# Altium schematic sheet 100W_Inverter_V1_Sch.SchDoc(100W_Inverter_V1_Sch)
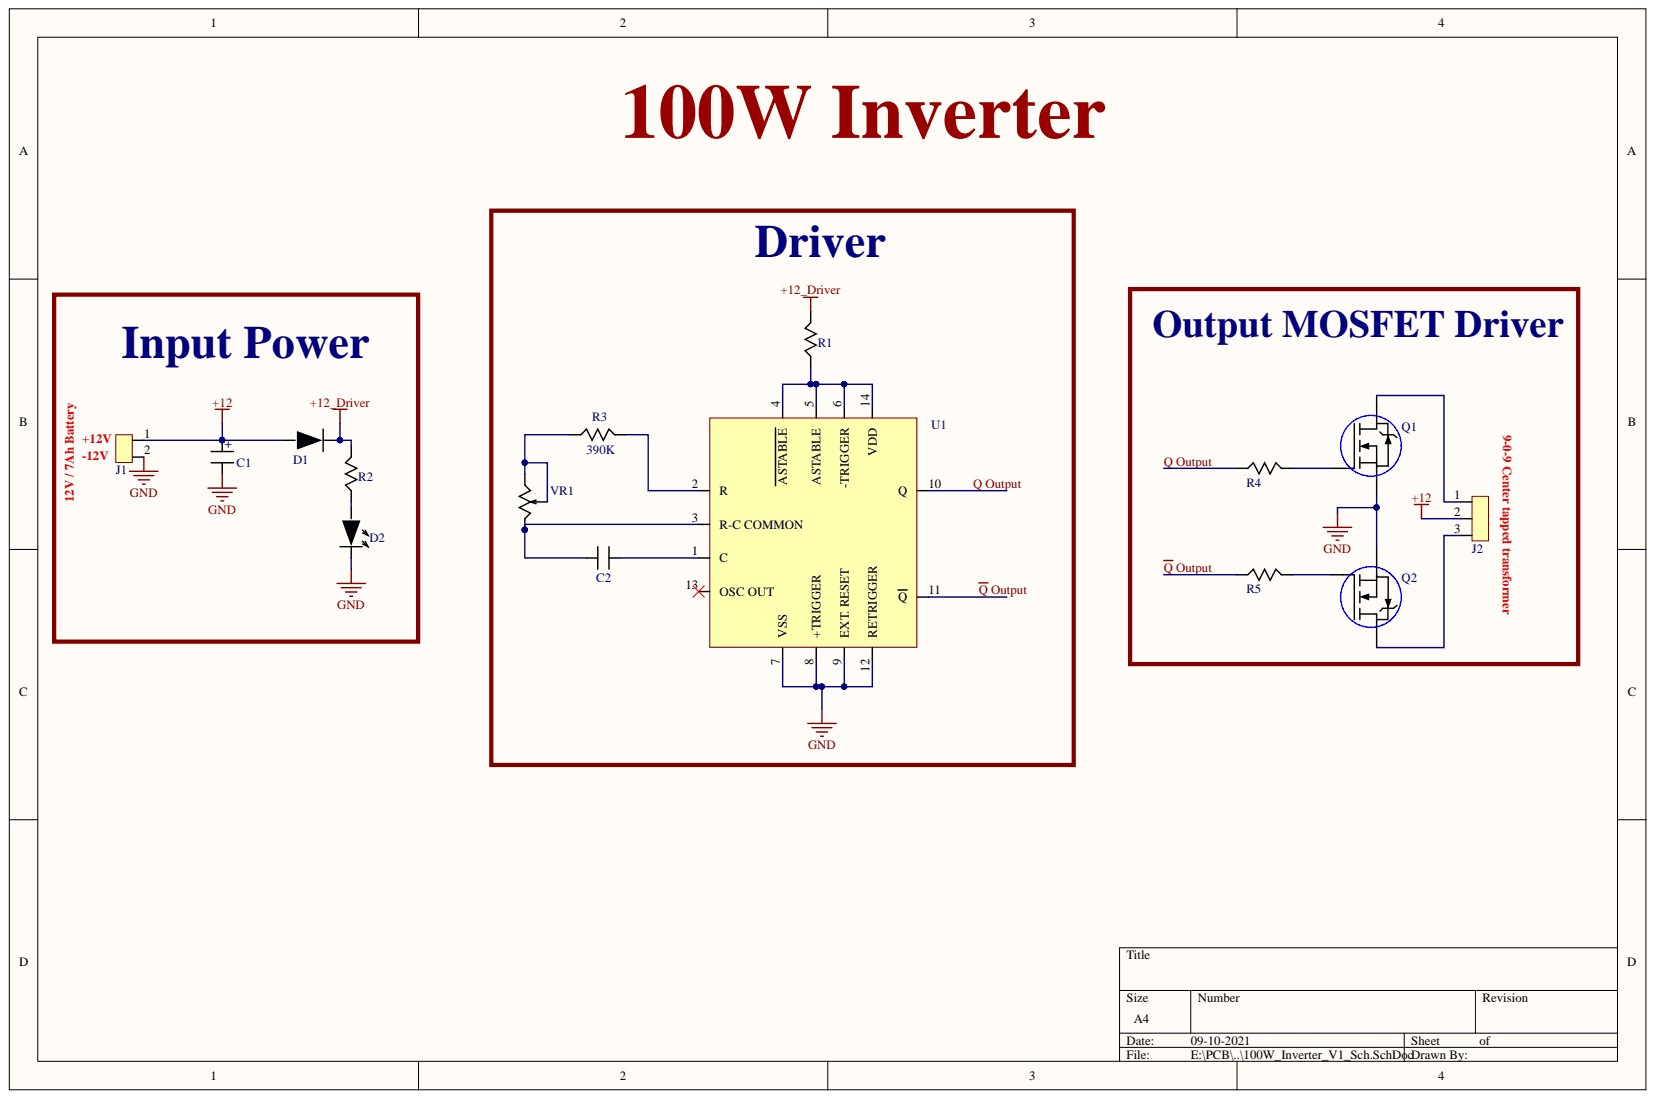
[diagram p0: 100W_Inverter_V1_Sch.SchDoc]

## Parts
C1  C_2200uF_Ely_35V_TH  [Ecap_8mmPitch_16d]  (value not drawn)
C2  C_0.01uF_Cer_50V_TH  [0.01uF_Cer_TH]  (value not drawn)
D1  1N4007  [Diode]  (value not drawn)
D2  LED_3mm_Red_TH  [LED_3MM_Red]  (value not drawn)
J1  TB_2P_5mmP  [TB_5mmP_2Pin]  (value not drawn)
J2  TB_3P_5mmP  [TB_5mmP_3Pin]  (value not drawn)
Q1  IRF540  [TO220]  (value not drawn)
Q2  IRF540  [TO220]  (value not drawn)
R1  R_330_0.25W_TH  [Axial 0.3]  (value not drawn)
R2  R_1K_0.25W_TH  [Axial 0.3]  (value not drawn)
R3  390K  [Axial 0.3]
R4  R_220_0.25W_TH  [Axial 0.3]  (value not drawn)
R5  R_220_0.25W_TH  [Axial 0.3]  (value not drawn)
U1  CD4047BE  [DIP14_8mmspace_2.54mmP]  (value not drawn)
VR1  VR_1K_trim_20T  [3290H]  (value not drawn)

## Nets
+12: C1.1 D1.1 J1.1 J2.2
+12_Driver: D1.2 R1.2 R2.2
GND: C1.2 D2.2 J1.2 Q1.3 Q2.3 U1.7(VSS) U1.8(+TRIGGER) U1.9(EXT._RESET) U1.12(RETRIGGER)
Q Output: R4.1 U1.10(Q)
~{Q} Output: R5.1 U1.11(Q)
NetC2_1: C2.1 U1.3(R-C_COMMON) VR1.3
NetC2_2: C2.2 U1.1(C)
NetD2_1: D2.1 R2.1
NetJ2_1: J2.1 Q1.2
NetJ2_3: J2.3 Q2.2
NetQ1_1: Q1.1 R4.2
NetQ2_1: Q2.1 R5.2
NetR1_1: R1.1 U1.4(ASTABLE) U1.5(ASTABLE) U1.6(-TRIGGER) U1.14(VDD)
NetR3_1: R3.1 VR1.1 VR1.2
NetR3_2: R3.2 U1.2(R)

Not connected: U1 13(OSC_OUT)

## Library data of the parts
C1 = C_2200uF_Ely_35V_TH: Aluminum Electrolytic Capacitor, Polarized, Aluminum (wet), 35V, 20% +Tol, 20% -Tol, 2200uF, Through Hole Mount; Manufacturer 1: CDE Illinois Capacitor; Manufacturer Part Number 1: 228CKR035M; Capacitance: 2.2mF; Dielectric Material: Aluminium; ESR (Equivalent Series Resistance): 121mR; Life (Hours): 2000Hour; Polarity: Polar; Ripple Current: 2.09A; Case/Package: Radial; Category: Aluminum Electrolytic Capacitors; Component Kind: Standard; ComponentLink1Description: https://datasheet.ciiva.com/16819/ckr-cks-16819885.pdf?src-supplier=Future+Electronics; ComponentLink1URL: https://datasheet.ciiva.com/16819/ckr-cks-16819885.pdf?src-supplier=Future+Electronics; Diameter: 16mm; Height: 31.5mm; Height - Seated (Max): 1.24inch; Lead Free: Lead Free; Lead Pitch: 0.295inch; Library Name: Sch_common.SchLib; Max Operating Temperature: 85°C; Min Operating Temperature: -40°C; Mount: Through Hole; Package Quantity: 50; Packaging: Bulk; Pricing 1: 1=1.52000, 10=1.20100, 50=1.04060, 100=0.90040, 500=0.68034, 1000=0.60030, 2500=0.56028, 5000=0.54027 (USD); REACH SVHC: No SVHC; RoHS: Yes; Stock 1: 3987; Supplier 1: Digi-Key; Tolerance: 20%; Voltage Rating: 35V; Voltage Rating (DC): 35V
C2 = C_0.01uF_Cer_50V_TH: Capacitor Ceramic 0.01uF 50V C0G 5% 5mm T/R; Manufacturer 1: TDK; Manufacturer Part Number 1: FA28NP01H103JNU06; Capacitance: 10nF; Lead/Base Style: Kinked; Case/Package: Radial; Category: Ceramic Capacitors; Component Kind: Standard; ComponentLink1Description: https://product.tdk.com/info/en/catalog/datasheets/leadmlcc_halogenfree_fa150_en.pdf?src-supplier=Digi-Key; ComponentLink1URL: https://product.tdk.com/info/en/catalog/datasheets/leadmlcc_halogenfree_fa150_en.pdf?src-supplier=Digi-Key; Features: High Temperature; Height - Seated (Max): 0.217inch; Lead Pitch: 0.197inch; Length: 0.157inch; Library Name: Sch_common.SchLib; Max Operating Temperature: 150°C; Min Operating Temperature: -55°C; Mount: Through Hole; Packaging: Tape and Reel; Pricing 1: 1=0.48000, 10=0.33700, 50=0.25500, 100=0.22220, 500=0.16456, 1000=0.13988 (USD); Ratings: AEC-Q200; RoHS: Yes; RoHS Compliant: Yes; Stock 1: 2762; Supplier 1: Digi-Key; Tolerance: 5%; Voltage Rating: 50V; Width: 0.098inch
D1 = 1N4007: Diode Switching 1KV 1A 2-Pin DO-41 T/R; Manufacturer 1: Diodes; Manufacturer Part Number 1: 1N4007G-T; Capacitance: 8pF; Element Configuration: Single; Forward Voltage: 1V; Max Reverse Current: 5uA; Max Surge Current: 30A; Polarity: Standard; Recovery Time: 2us; Average Rectified Current: 1A; Case/Package: DO-41; Category: Rectifier Diodes; Component Kind: Standard; ComponentLink1Description: https://datasheet.ciiva.com/36525/1537942-36525995.pdf?src-supplier=Jameco; ComponentLink1URL: https://datasheet.ciiva.com/36525/1537942-36525995.pdf?src-supplier=Jameco; ComponentLink2Description: https://datasheet.ciiva.com/15219/getdatasheetpartid-213960-15219547.pdf?src-supplier=Verical; ComponentLink2URL: https://datasheet.ciiva.com/15219/getdatasheetpartid-213960-15219547.pdf?src-supplier=Verical; ComponentLink3Description: https://datasheet.ciiva.com/25947/ds29002-25947029.pdf?src-supplier=Avnet+Europe; ComponentLink3URL: https://datasheet.ciiva.com/25947/ds29002-25947029.pdf?src-supplier=Avnet+Europe; ComponentLink4Description: https://datasheet.ciiva.com/912/ds29002-912230.pdf?src-supplier=Digi-Key; ComponentLink4URL: https://datasheet.ciiva.com/912/ds29002-912230.pdf?src-supplier=Digi-Key; ComponentLink5Description: https://datasheet.ciiva.com/16395/ds29002-16395514.pdf?src-supplier=Future+Electronics; ComponentLink5URL: https://datasheet.ciiva.com/16395/ds29002-16395514.pdf?src-supplier=Future+Electronics; Current Rating: 1A; Forward Current: 1A; Height: 2.71mm; Lead Free: Lead Free; Length: 4.7mm; Library Name: Sch_common.SchLib; Max Operating Temperature: 175°C; Max Repetitive Reverse Voltage (Vrrm): 1kV; Max Reverse Voltage (DC): 1kV; Min Operating Temperature: -65°C; Mount: Through Hole; Number of Pins: 2; Output Current: 1A; Package Quantity: 5000; Packaging: Tape and Reel; Peak Non-Repetitive Surge Current: 30A; Pricing 1: 1=0.30000, 10=0.24800, 100=0.13170, 500=0.08662, 1000=0.05890, 2000=0.05313 (USD); Radiation Hardening: No; Reverse Recovery Time: 2us; Reverse Voltage (DC): 1kV; RoHS: Yes; RoHS Compliant: Yes; Series: -; Stock 1: 144958; Supplier 1: Digi-Key; Voltage Rating (DC): 1kV; Weight: 0.010582oz; Width: 2.71mm
D2 = LED_3mm_Red_TH: Manufacturer 1: SunLED; Manufacturer Part Number 1: XLMDK34D; Category: LEDs; Component Kind: Standard; Library Name: Sch_common.SchLib; Pricing 1: 1=0.38000, 10=0.30000, 100=0.13470, 500=0.12168, 1000=0.09996, 2000=0.08692, 5000=0.07823, 10000=0.06954, 25000=0.06519 (USD); RoHS: No; Stock 1: 8836; Supplier 1: Digi-Key
J1 = TB_2P_5mmP: TERM BLOCK PLUG 2POS 90DEG 5MM; Manufacturer 1: On-Shore Technology; Manufacturer Part Number 1: OSTTH020100; Current: 15A; Number of Levels: 1; Number of Positions: 2; Pitch: 0.197inch; Voltage: 300V; Wire Gauge (Max): 22AWG; Wire Gauge (Min): 14AWG; Category: Terminal Blocks; Color: Black; Component Kind: Standard; ComponentLink1Description: http://www.on-shore.com/wp-content/uploads/2015/09/ostthxx0160.pdf?src-supplier=Digi-Key; ComponentLink1URL: http://www.on-shore.com/wp-content/uploads/2015/09/ostthxx0160.pdf?src-supplier=Digi-Key; Contact Plating: Nickel; Library Name: Sch_common.SchLib; Max Operating Temperature: 115°C; Min Operating Temperature: -40°C; Mount: Free Hanging; Number of Pins: 2; Number of Positions Per Level: 2; Packaging: Bulk; Pricing 1: 1=0.86000, 10=0.53400, 25=0.45680, 50=0.41080, 100=0.40220, 250=0.34232, 500=0.32518, 1000=0.29096, 5000=0.22820 (USD); RoHS: Yes; RoHS Compliant: No; Series: OSTTH; Stock 1: 527; Supplier 1: Digi-Key; Termination: Screw
J2 = TB_3P_5mmP: Res Thick Film 0603 100K Ohm 1% 0.1W(1/10W) ±100ppm/C Pad SMD Automotive T/R; Manufacturer 1: Panasonic; Manufacturer Part Number 1: ERJ3EKF1003V; Max Power Dissipation: 100mW; Resistance: 100kR; Temperature Coefficient: 100ppm/°C; Voltage Rating: 75V; Case Code (Imperial): 0603; Case Code (Metric): 1608; Case/Package: 0603; Category: Chip SMD Resistors; Component Kind: Standard; ComponentLink1Description: https://datasheet.ciiva.com/34303/1987476-34303566.pdf?src-supplier=Jameco; ComponentLink1URL: https://datasheet.ciiva.com/34303/1987476-34303566.pdf?src-supplier=Jameco; ComponentLink2Description: https://datasheet.ciiva.com/16770/70260748-16770253.pdf?src-supplier=Allied+Electronics; ComponentLink2URL: https://datasheet.ciiva.com/16770/70260748-16770253.pdf?src-supplier=Allied+Electronics; ComponentLink3Description: https://datasheet.ciiva.com/16740/aoa0000ce2-16740662.pdf?src-supplier=Future+Electronics; ComponentLink3URL: https://datasheet.ciiva.com/16740/aoa0000ce2-16740662.pdf?src-supplier=Future+Electronics; ComponentLink4Description: https://datasheet.ciiva.com/15158/getdatasheetpartid-247527-15158907.pdf?src-supplier=Verical; ComponentLink4URL: https://datasheet.ciiva.com/15158/getdatasheetpartid-247527-15158907.pdf?src-supplier=Verical; ComponentLink5Description: https://datasheet.ciiva.com/30133/aoa0000ce2-30133845.pdf?src-supplier=Onlinecomponents.com; ComponentLink5URL: https://datasheet.ciiva.com/30133/aoa0000ce2-30133845.pdf?src-supplier=Onlinecomponents.com; Construction: Rectangular; Contact Plating: Tin; Depth: 0.8mm; Features: Automotive AEC-Q200; Height: 0.022inch; Lead Free: Lead Free; Length: 1.6mm; Library Name: Sch_common.SchLib; Max Operating Temperature: 155°C; Military Standard: Not; Min Operating Temperature: -55°C; Mount: Surface Mount; Number of Pins: 2; Number of Terminations: 2; Package Quantity: 5000; Packaging: Tape and Reel; Power Rating: 100mW; Pricing 1: 1=0.10000, 10=0.03700, 100=0.01490, 1000=0.00670, 2500=0.00582 (USD); Radiation Hardening: No; REACH SVHC: No SVHC; Resistance Tolerance: 1%; Resistor Type: Thick Film; RoHS: Yes; RoHS Compliant: Yes; Series: ERJ; Size Code: 0603; Stock 1: 10082; Supplier 1: Digi-Key; Termination: SMD/SMT; Tolerance: 1%; Voltage Rating (DC): 75V; Weight: 7.1E-05oz; Width: 0.8mm
Q1 Q2 = IRF540: Mosfet, Power; N-ch; Vdss 100V; Rds(on) 21MILLIOHMS; Id 36A; TO-262; Pd 92W; Vgs +/-20; Manufacturer 1: Infineon; Manufacturer Part Number 1: IRF540ZLPBF; Drain to Source Resistance: 26.5mR; Gate to Source Voltage (Vgs): 20V; Input Capacitance: 1.77nF; Turn-Off Delay Time: 43ns; Turn-On Delay Time: 15ns; Case/Package: TO-262; Category: MOSFETs; Component Kind: Standard; ComponentLink1Description: https://www.alliedelec.com/m/d/23899d70707f5ac9a5c306f9e15d4494.pdf?src-supplier=Allied+Electronics; ComponentLink1URL: https://www.alliedelec.com/m/d/23899d70707f5ac9a5c306f9e15d4494.pdf?src-supplier=Allied+Electronics; ComponentLink2Description: http://www.infineon.com/dgdl/irf540zpbf.pdf?fileId=5546d462533600a4015355e3af7d19a6&src-supplier=Digi-Key; ComponentLink2URL: http://www.infineon.com/dgdl/irf540zpbf.pdf?fileId=5546d462533600a4015355e3af7d19a6&src-supplier=Digi-Key; Continuous Drain Current (ID): 36A; Drain to Source Breakdown Voltage: 100V; Drain to Source Voltage (Vdss): 100V; Halogen Free: Halogen Free; Height: 0.38inch; Lead Free: Contains Lead; Length: 0.42inch; Library Name: Sch_common.SchLib; Max Dual Supply Voltage: 100V; Max Operating Temperature: 175°C; Max Power Dissipation: 92W; Min Operating Temperature: -55°C; Mount: Through Hole; Number of Pins: 3; Packaging: Rail/Tube; Power Dissipation: 92W; Rds On Max: 26.5mR; RoHS: Yes; RoHS Compliant: Yes; Supplier 1: Newark; Width: 0.19inch
R1 = R_330_0.25W_TH: TE Connectivity CFR16 Series Axial Carbon Film Resistor 330 5% 0.25W -1200  0ppm/C; Manufacturer Part Number 1: CFR16J330RJIT; Power Rating: 250mW; Resistance: 330R; Temperature Coefficient: 0ppm/°C; Case/Package: Conformal; Component Kind: Standard; ComponentLink1Description: https://datasheet.ciiva.com/11823/0900766b80098b49-11823361.pdf?src-supplier=RSComponents; ComponentLink1URL: https://datasheet.ciiva.com/11823/0900766b80098b49-11823361.pdf?src-supplier=RSComponents; Diameter: 1.85mm; Length: 3.5mm; Library Name: Sch_common.SchLib; Manufacturer 1: TE Connectivity; Max Operating Temperature: 155°C; Min Operating Temperature: -55°C; RoHS: Yes; Stock 1: 1; Supplier 1: RSComponents; Termination: Axial; Tolerance: 5%
R2 = R_1K_0.25W_TH: TE Connectivity CFR16 Series Axial Carbon Film Resistor 1k 5% 0.25W -1200  0ppm/C; Manufacturer Part Number 1: CFR16J1K0; Max Power Dissipation: 250mW; Number of Resistors: 1; Resistance: 1kR; Temperature Coefficient: 0ppm/°C; Voltage Rating: 200V; Case/Package: Axial; Category: Through-Hole Resistors; Component Kind: Standard; ComponentLink1Description: https://datasheet.ciiva.com/11823/0900766b80098b49-11823166.pdf?src-supplier=RSComponents; ComponentLink1URL: https://datasheet.ciiva.com/11823/0900766b80098b49-11823166.pdf?src-supplier=RSComponents; ComponentLink2Description: https://datasheet.ciiva.com/24428/eng-ds-1773195-d-24428424.pdf?src-supplier=Onlinecomponents.com; ComponentLink2URL: https://datasheet.ciiva.com/24428/eng-ds-1773195-d-24428424.pdf?src-supplier=Onlinecomponents.com; ComponentLink3Description: https://datasheet.ciiva.com/22664/1723854-22664943.pdf?src-supplier=Element14; ComponentLink3URL: https://datasheet.ciiva.com/22664/1723854-22664943.pdf?src-supplier=Element14; Diameter: 1.85mm; ELV: Compliant; Length: 3.5mm; Library Name: Sch_common.SchLib; Manufacturer 1: TE Connectivity; Max Operating Temperature: 155°C; Min Operating Temperature: -55°C; Mount: Axial; Number of Terminations: 2; Packaging: Cut Tape; Power Rating: 250mW; Pricing 1: 1=0.10000, 10=0.07900, 25=0.05440, 50=0.04240, 100=0.03020, 250=0.02360, 500=0.01876, 1000=0.01331 (USD); Radiation Hardening: No; REACH SVHC: No SVHC; Resistance Tolerance: 5%; Resistor Type: Thin Film; RoHS: Yes; RoHS Compliant: Yes; Series: CFR16, Neohm; Stock 1: 4940; Supplier 1: Digi-Key; Technology: CARBON FILM; Termination: Axial; Tolerance: 5%; Voltage Rating (DC): 200V
R3 = R_390K_0.25W_TH: RES 390K OHM 1/4W 5% AXIAL; Manufacturer 1: Yageo; Manufacturer Part Number 1: CFR-25JB-52-390K; Max Power Dissipation: 250mW; Resistance: 390kR; Temperature Coefficient: -700ppm/°C; Case/Package: Axial; Category: Through-Hole Resistors; Component Kind: Standard; ComponentLink1Description: https://datasheet.ciiva.com/2713/yageolr-cfr-2013-2713170.pdf?src-supplier=Digi-Key; ComponentLink1URL: https://datasheet.ciiva.com/2713/yageolr-cfr-2013-2713170.pdf?src-supplier=Digi-Key; Lead Free: Lead Free; Length: 0.248inch; Library Name: Sch_common.SchLib; Max Operating Temperature: 155°C; Min Operating Temperature: -55°C; Number of Terminations: 2; Package Quantity: 200; Packaging: Bulk; Pricing 1: 1=0.10000, 10=0.06700, 25=0.04640, 50=0.03600, 100=0.02580, 250=0.02008, 500=0.01596, 1000=0.01133, 5000=0.00876 (USD); Resistor Type: Carbon Film; RoHS: Yes; RoHS Compliant: Yes; Series: CFR; Stock 1: 11679; Supplier 1: Digi-Key; Tolerance: 5%
R4 R5 = R_220_0.25W_TH: RES 220 OHM 1/4W 5% AXIAL; Manufacturer 1: Stackpole Electronics; Manufacturer Part Number 1: CF14JT220R; Max Power Dissipation: 250mW; Resistance: 220R; Temperature Coefficient: -400ppm/°C; Case/Package: Conformal; Category: Through-Hole Resistors; Component Kind: Standard; ComponentLink1Description: https://www.seielect.com/catalog/SEI-Packaging.pdf?src-supplier=Digi-Key; ComponentLink1URL: https://www.seielect.com/catalog/SEI-Packaging.pdf?src-supplier=Digi-Key; ComponentLink2Description: https://www.seielect.com/catalog/SEI-CF_CFM.pdf?src-supplier=Avnet; ComponentLink2URL: https://www.seielect.com/catalog/SEI-CF_CFM.pdf?src-supplier=Avnet; ComponentLink3Description: https://www.verical.com/server-webapp/html/getDatasheet?partID=375100&src-supplier=Verical; ComponentLink3URL: https://www.verical.com/server-webapp/html/getDatasheet?partID=375100&src-supplier=Verical; ComponentLink4Description: http://components.arrow.com/part/dataview/44988614S316465N1174?src-supplier=Arrow+Electronics; ComponentLink4URL: http://components.arrow.com/part/dataview/44988614S316465N1174?src-supplier=Arrow+Electronics; Construction: Cylindrical; Contact Plating: Tin,Matte; Diameter: 2.3mm; Features: Flame Retardant Coating; Lead Free: Lead Free; Length: 6.5mm; Library Name: Sch_common.SchLib; Max Operating Temperature: 155°C; Military Standard: Not; Min Operating Temperature: -55°C; Mount: Through Hole,Axial; Number of Terminations: 2; Package Quantity: 1; Packaging: Tape and Reel; Power Rating: 250mW; Pricing 1: 1=0.10000, 10=0.03600, 25=0.02640, 50=0.02000, 100=0.01480, 250=0.01124, 500=0.00900, 1000=0.00662 (USD); Radiation Hardening: No; Resistor Type: Carbon Film; RoHS: Yes; RoHS Compliant: Yes; Series: CF; Stock 1: 142794; Supplier 1: Digi-Key; Termination: Axial; Tolerance: 5%
U1 = CD4047BE: MONO/ASTABLE MULTIVIBRATOR, 125NS, DIP-14; Multivibrator Type:Monostable / Astable; Output Current:6.8A; Supply Voltage Min:3V; Supply Voltage Max:18V; Logic Case Style:DIP; No. of Pins:14; Propagation Delay:125ns; Packaging:Each ;RoHS Compliant: Yes; Manufacturer 1: Texas Instruments; High Level Output Current: -4.2mA; Independent Circuits: 1; Input Capacitance: 5pF; Low Level Output Current: 4.2mA; Max Power Dissipation: 500mW; Max Supply Current: 20uA; Max Supply Voltage: 18V; Min Supply Voltage: 3V; Operating Supply Voltage: 12V; Output Current: 6.8mA; Schmitt Trigger Input: No; Turn-On Delay Time: 300ns; Case/Package: DIP; Category: Logic ICs; Component Kind: Standard; ComponentLink1Description: https://datasheet.ciiva.com/14134/cd4047b-eng-tds-14134622.pdf?src-supplier=Distrelec; ComponentLink1URL: https://datasheet.ciiva.com/14134/cd4047b-eng-tds-14134622.pdf?src-supplier=Distrelec; ComponentLink2Description: https://datasheet.ciiva.com/27050/894331-27050683.pdf?src-supplier=Jameco; ComponentLink2URL: https://datasheet.ciiva.com/27050/894331-27050683.pdf?src-supplier=Jameco; ComponentLink3Description: https://datasheet.ciiva.com/15095/getdatasheetpartid-72002-15095147.pdf?src-supplier=Verical; ComponentLink3URL: https://datasheet.ciiva.com/15095/getdatasheetpartid-72002-15095147.pdf?src-supplier=Verical; ComponentLink4Description: https://datasheet.ciiva.com/41455/001015487-da-01-en-ic-mono-astbl-multi-cd4047be-pdip-14-tid-41455405.pdf?src-supplier=Conrad; ComponentLink4URL: https://datasheet.ciiva.com/41455/001015487-da-01-en-ic-mono-astbl-multi-cd4047be-pdip-14-tid-41455405.pdf?src-supplier=Conrad; ComponentLink5Description: https://datasheet.ciiva.com/29297/173223-da-01-en-c-mos-ic-cd-4047-be-dip14-tid-29297339.pdf?src-supplier=Conrad; ComponentLink5URL: https://datasheet.ciiva.com/29297/173223-da-01-en-c-mos-ic-cd-4047-be-dip14-tid-29297339.pdf?src-supplier=Conrad; ComponentLink6Description: https://datasheet.ciiva.com/1509/1830980-1509169.pdf?src-supplier=Element14; ComponentLink6URL: https://datasheet.ciiva.com/1509/1830980-1509169.pdf?src-supplier=Element14; ComponentLink7Description: https://datasheet.ciiva.com/1885/cd4047b-1885142.pdf?src-supplier=Digi-Key; ComponentLink7URL: https://datasheet.ciiva.com/1885/cd4047b-1885142.pdf?src-supplier=Digi-Key; ComponentLink8Description: https://datasheet.ciiva.com/3305/cd4047b-3305349.pdf?src-supplier=Avnet; ComponentLink8URL: https://datasheet.ciiva.com/3305/cd4047b-3305349.pdf?src-supplier=Avnet; ComponentLink9Description: https://datasheet.ciiva.com/26242/cd4047b-26242053.pdf?src-supplier=Avnet+Europe; ComponentLink9URL: https://datasheet.ciiva.com/26242/cd4047b-26242053.pdf?src-supplier=Avnet+Europe; Contact Plating: Gold; Height: 4.57mm; Lead Free: Lead Free; Length: 19.3mm; Library Name: Sch_common.SchLib; Logic Function: Multivibrator; Manufacturer Part Number 1: CD4047BE; Max Operating Temperature: 125°C; Min Operating Temperature: -55°C; Mount: Through Hole; Number of Bits: 1; Number of Circuits: 1; Number of Elements: 1; Number of Pins: 14; Package Quantity: 25; Packaging: Rail/Tube; Power Dissipation: 500mW; Pricing 1: 1=0.74000, 10=0.64700, 25=0.60800, 100=0.49610, 250=0.46084, 500=0.39220, 1000=0.32375 (USD); Propagation Delay: 125ns; Quiescent Current: 20uA; Radiation Hardening: No; REACH SVHC: No SVHC; RoHS: Yes; Stock 1: 162; Supplier 1: Digi-Key; Weight: 0.032734oz; Width: 6.35mm
VR1 = VR_1K_trim_20T: TRIMMER 1K OHM 1W PC PIN; Manufacturer 1: Bourns; Manufacturer Part Number 1: 3290H-1-102M; Number of Turns: 25; Resistance: 1kR; Temperature Coefficient: 50ppm/°C; Tolerance: 5%; Category: Variable Resistors and Potentiometers; Component Kind: Standard; ComponentLink1Description: https://datasheet.ciiva.com/2165/3290-2165858.pdf?src-supplier=Digi-Key; ComponentLink1URL: https://datasheet.ciiva.com/2165/3290-2165858.pdf?src-supplier=Digi-Key; ComponentLink2Description: https://datasheet.ciiva.com/16813/3290-16813453.pdf?src-supplier=Avnet+Europe; ComponentLink2URL: https://datasheet.ciiva.com/16813/3290-16813453.pdf?src-supplier=Avnet+Europe; Contact Plating: Gold; Depth: 6.1mm; Height: 0.375inch; Length: 17.78mm; Library Name: Sch_common.SchLib; Max Operating Temperature: 150°C; Max Power Dissipation: 1W; Military Standard: Not; Min Operating Temperature: -65°C; Mount: PCB,Through Hole,Panel; Package Quantity: 50; Packaging: Rail/Tube; Power Rating: 1W; Pricing 1: 50=38.65400 (USD); Resistor Type: Wirewound; RoHS: Yes; RoHS Compliant: Yes; Series: Trimpot® 3290 - Sealed; Supplier 1: Digi-Key; Taper: Linear; Termination: Pin; Weight: 0.025oz
